# Altium schematic sheet InputCircuitsAndSupply.SchDoc
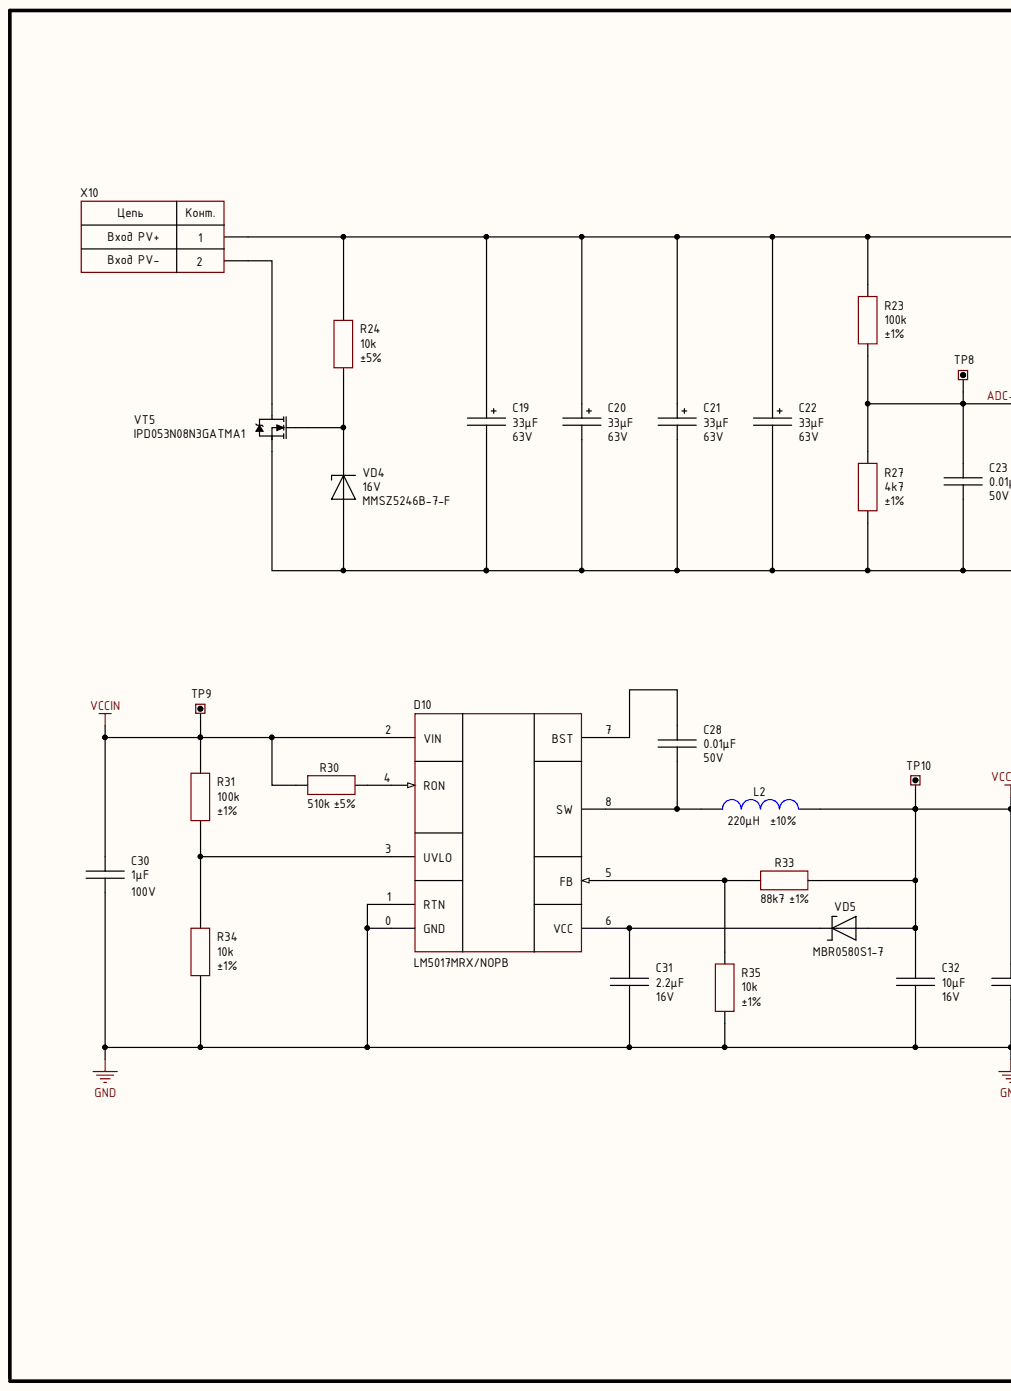
[diagram p0: InputCircuitsAndSupply.SchDoc, left]
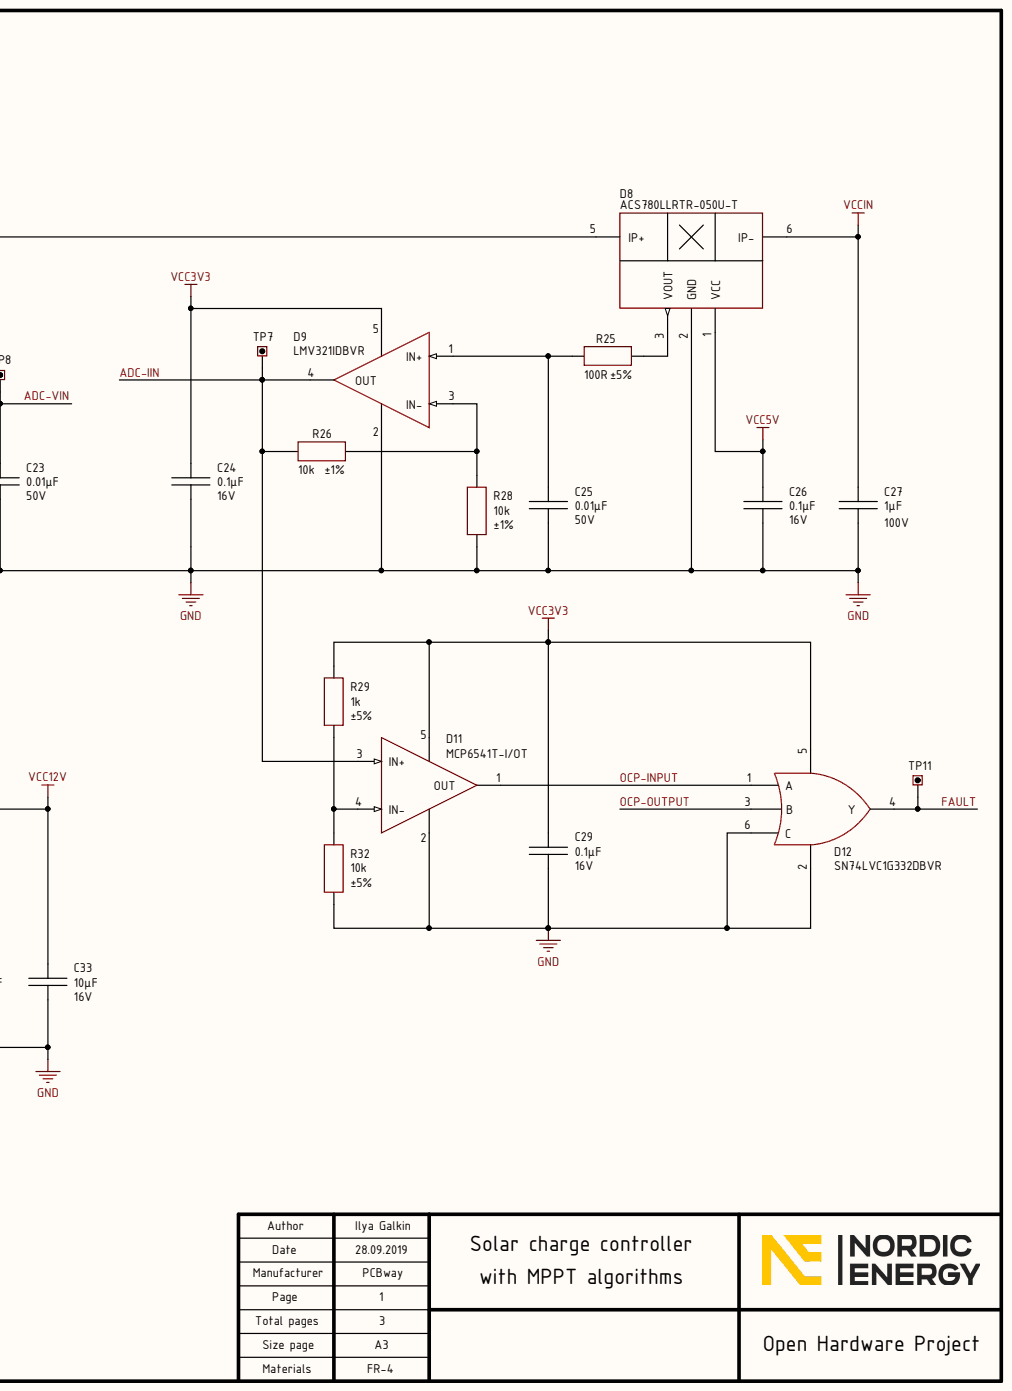
[diagram p1: InputCircuitsAndSupply.SchDoc, right]

## Parts
C19  value 33μF  Voltage: 63V  [CAP: D8C3.5H12]  (hidden comment: Aluminium capacitor, 33μF, 63V, ±20%, 25mOhm, 12x8x3.5 mm, -55...+105°C)
C20  value 33μF  Voltage: 63V  [CAP: D8C3.5H12]  (hidden comment: Aluminium capacitor, 33μF, 63V, ±20%, 25mOhm, 12x8x3.5 mm, -55...+105°C)
C21  value 33μF  Voltage: 63V  [CAP: D8C3.5H12]  (hidden comment: Aluminium capacitor, 33μF, 63V, ±20%, 25mOhm, 12x8x3.5 mm, -55...+105°C)
C22  value 33μF  Voltage: 63V  [CAP: D8C3.5H12]  (hidden comment: Aluminium capacitor, 33μF, 63V, ±20%, 25mOhm, 12x8x3.5 mm, -55...+105°C)
C23  value 0.01μF  Voltage: 50V  [CAP: 0805]  (hidden comment: Ceramic capacitor, 0.01μF, X7R, ±10%, 50V, 0805, -55...+125°C)
C24  value 0.1μF  Voltage: 16V  [CAP: 0805]  (hidden comment: Ceramic capacitor, 0.1μF, X7R, ±10%, 16V, 0805, -55...+125°C)
C25  value 0.01μF  Voltage: 50V  [CAP: 0805]  (hidden comment: Ceramic capacitor, 0.01μF, X7R, ±10%, 50V, 0805, -55...+125°C)
C26  value 0.1μF  Voltage: 16V  [CAP: 0805]  (hidden comment: Ceramic capacitor, 0.1μF, X7R, ±10%, 16V, 0805, -55...+125°C)
C27  value 1μF  Voltage: 100V  [CAP: 1206]  (hidden comment: Ceramic capacitor, 1μF, X7R, ±10%, 100V, 1206, -55...+125°C)
C28  value 0.01μF  Voltage: 50V  [CAP: 0805]  (hidden comment: Ceramic capacitor, 0.01μF, X7R, ±10%, 50V, 0805, -55...+125°C)
C29  value 0.1μF  Voltage: 16V  [CAP: 0805]  (hidden comment: Ceramic capacitor, 0.1μF, X7R, ±10%, 16V, 0805, -55...+125°C)
C30  value 1μF  Voltage: 100V  [CAP: 1206]  (hidden comment: Ceramic capacitor, 1μF, X7R, ±10%, 100V, 1206, -55...+125°C)
C31  value 2.2μF  Voltage: 16V  [CAP: 0805]  (hidden comment: Ceramic capacitor, 2.2μF, X7R, ±10%, 16V, 0805, -55...+125°C)
C32  value 10μF  Voltage: 16V  [CAP: 0805]  (hidden comment: Ceramic capacitor, 10μF, X7R, ±10%, 16V, 0805, -55...+125°C)
C33  value 10μF  Voltage: 16V  [CAP: 0805]  (hidden comment: Ceramic capacitor, 10μF, X7R, ±10%, 16V, 0805, -55...+125°C)
D8  PartNumber: ACS780LLRTR-050U-T  [SENSOR: ACS781]  (hidden comment: IC current sensors, 50A, ±1.5%, 120 kHz, 60mV/A, -40...+150°C, 7-PSOF)
D9  IC op amplifier, Rail-to-Rail, 1 MHz, 2.7...5.5V, -40...+125°C, SOT23-5  [SOT23-5]  (value not drawn)
D10  PartNumber: LM5017MRX/NOPB  [8-SOIC-PAD]  (hidden comment: https://www.digikey.com/product-detail/en/texas-instruments/LM5017MRX-NOPB/296-41308-1-ND/5222776, input 7.5...100V, 1MHz, output 1.225...90V, 0.6A, -40...+125°C, 8-SOIC)
D11  IC comparator, Rail-to-Rail, 1.6...5.5V, -40...+85°C, SOT23-5  [SOT23-5]  (value not drawn)
D12  IC logic OR, 1.65...5.5V, -40...+125°C, SOT23-6  [SOT23-6]  (value not drawn)
L2  value 220μH  Tolerance: ±10%  [IND: SDR0805]  (hidden comment: Fixed inductor, 220μH, ±10%, SDR0805, 490mA, -40...+125°C)
R23  value 100k  Tolerance: ±1%  [RES: 0805]  (hidden comment: Resistor film, 100k, ±1%, 0805, 0.125W, -55...+125°C)
R24  value 10k  Tolerance: ±5%  [RES: 0805]  (hidden comment: Resistor film, 10k, ±5%, 0805, 0.125W, -55...+125°C)
R25  value 100R  Tolerance: ±5%  [RES: 0805]  (hidden comment: Resistor film, 100R, ±5%, 0805, 0.125W, -55...+125°C)
R26  value 10k  Tolerance: ±1%  [RES: 0805]  (hidden comment: Resistor film, 10k, ±1%, 0805, 0.125W, -55...+125°C)
R27  value 4k7  Tolerance: ±1%  [RES: 0805]  (hidden comment: Resistor film, 4k7, ±1%, 0805, 0.125W, -55...+125°C)
R28  value 10k  Tolerance: ±1%  [RES: 0805]  (hidden comment: Resistor film, 10k, ±1%, 0805, 0.125W, -55...+125°C)
R29  value 1k  Tolerance: ±5%  [RES: 0805]  (hidden comment: Resistor film, 1k, ±5%, 0805, 0.125W, -55...+125°C)
R30  value 510k  Tolerance: ±5%  [RES: 0805]  (hidden comment: Resistor film, 510k, ±5%, 0805, 0.125W, -55...+125°C)
R31  value 100k  Tolerance: ±1%  [RES: 0805]  (hidden comment: Resistor film, 100k, ±1%, 0805, 0.125W, -55...+125°C)
R32  value 10k  Tolerance: ±5%  [RES: 0805]  (hidden comment: Resistor film, 10k, ±5%, 0805, 0.125W, -55...+125°C)
R33  value 88k7  Tolerance: ±1%  [RES: 0805]  (hidden comment: Resistor film, 88k7, ±1%, 0805, 0.125W, -55...+125°C)
R34  value 10k  Tolerance: ±1%  [RES: 0805]  (hidden comment: Resistor film, 10k, ±1%, 0805, 0.125W, -55...+125°C)
R35  value 10k  Tolerance: ±1%  [RES: 0805]  (hidden comment: Resistor film, 10k, ±1%, 0805, 0.125W, -55...+125°C)
TP7  Test point, Keystone, 5001  [CON: 5004 (Keystone)]  (value not drawn)
TP8  Test point, Keystone, 5001  [CON: 5004 (Keystone)]  (value not drawn)
TP9  Test point, Keystone, 5001  [CON: 5001 (Keystone)]  (value not drawn)
TP10  Test point, Keystone, 5001  [CON: 5001 (Keystone)]  (value not drawn)
TP11  Test point, Keystone, 5001  [CON: 5001 (Keystone)]  (value not drawn)
VD4  PartNumber: MMSZ5246B-7-F  Voltage: 16V  [DIODE: SOD123]  (hidden comment: Zener diode, 16V, 500mW, 0.9V, 17Ohm, SOD-123, -65...+150°C)
VD5  PartNumber: MBR0580S1-7  [DIODE: SOD123]  (hidden comment: Schottky diode, 80V, 500mA, 1V, 15pF, SOD-123, -55...+150°C)
VT5  PartNumber: IPD053N08N3GATMA1  [DPAK]  (hidden comment: MOSFET N-channel transistor, 80V, 90A, 69nC, 150W, 5.3mOhm, 4750pF, Dpak, -55...+150°C)
X10  Connector, 2pin, 9.53mm, 300VAC, 25A  [CON: 6PCV-02-006]  (value not drawn)

## Nets
GND: C19.2 C20.2 C21.2 C22.2 C23.1 C24.1 C25.1 C26.1 C27.1 C29.1 C30.1 C31.1 C32.1 C33.1 D8.2(GND) D9.2 D10.0(GND) D10.1(RTN) D11.2 D12.2 D12.6(C) R27.2 R28.2 R32.2 R34.2 R35.2 VD4.1 VT5.2
VCC3V3: C24.2 C29.2 D9.5 D11.5 D12.5 R29.1
VCC5V: C26.2 D8.1(VCC)
VCC12V: C32.2 C33.2 L2.1 R33.2 TP10.1 VD5.1
VCCIN: C27.2 C30.2 D8.6(IP-) D10.2(VIN) R30.1 R31.2 TP9.1
ADC-IIN: D9.4(OUT) D11.3(IN+) R26.1 TP7.1
ADC-VIN: C23.2 R23.2 R27.1 TP8.1
FAULT: D12.4(Y) TP11.1
OCP-INPUT: D11.1(OUT) D12.1(A)
OCP-OUTPUT: D12.3(B)
NetC19_1: C19.1 C20.1 C21.1 C22.1 D8.5(IP+) R23.1 R24.1 X10.1
NetC25_2: C25.2 D9.1(IN+) R25.1
NetC28_1: C28.1 D10.8(SW) L2.2
NetC28_2: C28.2 D10.7(BST)
NetC31_2: C31.2 D10.6(VCC) VD5.2
NetD8_3: D8.3(VOUT) R25.2
NetD9_3: D9.3(IN-) R26.2 R28.1
NetD10_3: D10.3(UVLO) R31.1 R34.1
NetD10_4: D10.4(RON) R30.2
NetD10_5: D10.5(FB) R33.1 R35.1
NetD11_4: D11.4(IN-) R29.2 R32.1
NetR24_2: R24.2 VD4.2 VT5.1
NetVT5_3: VT5.3 X10.2

## Library data of the parts
C19-C22 = Aluminium: Manufacturer: Panasonic; PartNumber: 63SXE33M; Component Kind: Standard; HelpURL: https://www.digikey.com/products/en?keywords=%2063SXE33M; Impedance: 25mOhm; Library Name: Capacitor.IntLib; Package: 12x8x3.5 mm; Temperature: -55...+105°C; Tolerance: ±20%; TypeCapacitor: Aluminium capacitor; ValueManufacturerPartNumber: Aluminium capacitor, 33μF, 63V, ±20%, 25mOhm, 12x8x3.5 mm, -55...+105°C
C23 C25 C28 = Ceramic_0805: Manufacturer: Yageo; PartNumber: CC0805KRX7R9BB103; Component Kind: Standard; HelpURL: https://www.digikey.com/product-detail/en/yageo/CC0805KRX7R9BB103/311-1136-1-ND/303046; Library Name: Capacitor.IntLib; Package: 0805; Temperature: -55...+125°C; Tolerance: ±10%; TypeCapacitor: Ceramic capacitor; TypeDielectric: X7R; ValueManufacturerPartNumber: Ceramic capacitor, 0.01μF, X7R, ±10%, 50V, 0805, -55...+125°C
C24 C26 C29 = Ceramic_0805: Manufacturer: Yageo; PartNumber: CC0805KRX7R7BB104; Component Kind: Standard; HelpURL: https://www.digikey.com/product-detail/en/yageo/CC0805KRX7R7BB104/311-1142-1-ND/303052; Library Name: Capacitor.IntLib; Package: 0805; Temperature: -55...+125°C; Tolerance: ±10%; TypeCapacitor: Ceramic capacitor; TypeDielectric: X7R; ValueManufacturerPartNumber: Ceramic capacitor, 0.1μF, X7R, ±10%, 16V, 0805, -55...+125°C
C27 C30 = Ceramic_1206: Manufacturer: Samsung; PartNumber: CL31B105KCHNNNE; Component Kind: Standard; HelpURL: https://www.digikey.com/product-detail/en/samsung-electro-mechanics/CL31B105KCHNNNE/1276-1838-1-ND/3889924; Library Name: Capacitor.IntLib; Package: 1206; Temperature: -55...+125°C; Tolerance: ±10%; TypeCapacitor: Ceramic capacitor; TypeDielectric: X7R; ValueManufacturerPartNumber: Ceramic capacitor, 1μF, X7R, ±10%, 100V, 1206, -55...+125°C
C31 = Ceramic_0805: Manufacturer: Yageo; PartNumber: CC0805KKX7R7BB225; Component Kind: Standard; HelpURL: https://www.digikey.com/product-detail/en/yageo/CC0805KKX7R7BB225/311-1881-1-ND/5195783; Library Name: Capacitor.IntLib; Package: 0805; Temperature: -55...+125°C; Tolerance: ±10%; TypeCapacitor: Ceramic capacitor; TypeDielectric: X7R; ValueManufacturerPartNumber: Ceramic capacitor, 2.2μF, X7R, ±10%, 16V, 0805, -55...+125°C
C32 C33 = Ceramic_0805: Manufacturer: Samsung; PartNumber: CL21B106KOQNNNG; Component Kind: Standard; HelpURL: https://www.digikey.com/product-detail/en/samsung-electro-mechanics/CL21B106KOQNNNG/1276-6472-1-ND/5958100; Library Name: Capacitor.IntLib; Package: 0805; Temperature: -55...+125°C; Tolerance: ±10%; TypeCapacitor: Ceramic capacitor; TypeDielectric: X7R; ValueManufacturerPartNumber: Ceramic capacitor, 10μF, X7R, ±10%, 16V, 0805, -55...+125°C
D8 = ACS780: Current sensors; Manufacturer: Allegro MicroSystems; GainBandwidth: 120 kHz; Supply: 4.5...5.5V; Accuracy: ±1.5%; Component Kind: Standard; ComponentType: IC current sensors; HelpURL: https://www.digikey.com/product-detail/en/allegro-microsystems-llc/ACS780LLRTR-050U-T/620-1818-1-ND/6189107; Library Name: IC. Sensor.IntLib; Package: 7-PSOF; Sensing: 50A; Sensitivity: 60mV/A; Temperature: -40...+150°C; ValueManufacturerPartNumber: IC current sensors, 50A, ±1.5%, 120 kHz, 60mV/A, -40...+150°C, 7-PSOF
D9 = LMV321IDBVR: OP amplifier; Manufacturer: Texas Instruments; GainBandwidth: 1 MHz; Component Kind: Standard; ComponentType: IC op amplifier; HelpURL: https://www.digikey.com/product-detail/en/texas-instruments/LMV321IDBVR/296-9567-1-ND/379849; Library Name: IC. Amplifier.IntLib; OutputType: Rail-to-Rail; Package: SOT23-5; PartNumber: LMV321IDBVR; Supply: 2.7...5.5V; Temperature: -40...+125°C; ValueManufacturerPartNumber: IC op amplifier, Rail-to-Rail, 1 MHz, 2.7...5.5V, -40...+125°C, SOT23-5
D10 = LM5017MRX: Buck converter; Manufacturer: Texas Instruments; Component Kind: Standard; ComponentType: https://www.digikey.com/product-detail/en/texas-instruments/LM5017MRX-NOPB/296-41308-1-ND/5222776; CurrentOutput: 0.6A; Frequency: 1MHz; HelpURL: https://www.digikey.com/product-detail/en/texas-instruments/LM5017SD-NOPB/LM5017SD-NOPBCT-ND/3137455; Library Name: IC. Power supply.IntLib; Package: 8-SOIC; Temperature: -40...+125°C; ValueManufacturerPartNumber: https://www.digikey.com/product-detail/en/texas-instruments/LM5017MRX-NOPB/296-41308-1-ND/5222776, input 7.5...100V, 1MHz, output 1.225...90V, 0.6A, -40...+125°C, 8-SOIC; VoltageInput: input 7.5...100V; VoltageOutput: output 1.225...90V
D11 = MCP6541T-I/OT: Comparator; Manufacturer: Microchip Technology; Component Kind: Standard; ComponentType: IC comparator; HelpURL: https://www.digikey.com/product-detail/en/microchip-technology/MCP6541T-I-OT/MCP6541T-I-OTCT-ND/3622387; Library Name: IC. Comparator.IntLib; OutputType: Rail-to-Rail; Package: SOT23-5; PartNumber: MCP6541T-I/OT; Supply: 1.6...5.5V; Temperature: -40...+85°C; ValueManufacturerPartNumber: IC comparator, Rail-to-Rail, 1.6...5.5V, -40...+85°C, SOT23-5
D12 = SN74LVC1G332DBVR: OR; Manufacturer: Texas Instruments; Component Kind: Standard; ComponentType: IC logic OR; HelpURL: https://www.digikey.com/product-detail/en/texas-instruments/SN74LVC1G332DBVR/296-18587-1-ND/857355; Library Name: IC. Logic.IntLib; Package: SOT23-6; PartNumber: SN74LVC1G332DBVR; Supply: 1.65...5.5V; Temperature: -40...+125°C; ValueManufacturerPartNumber: IC logic OR, 1.65...5.5V, -40...+125°C, SOT23-6
L2 = Inductor_SDR0805: Manufacturer: Bourns; PartNumber: SDR0805-221KL; Component Kind: Standard; HelpURL: https://www.digikey.com/product-detail/en/bourns-inc/SDR0805-221KL/SDR0805-221KLCT-ND/2127164; Library Name: Inductor.IntLib; MaxCurrent: 490mA; Package: SDR0805; Temperature: -40...+125°C; TypeInductor: Fixed inductor; ValueManufacturerPartNumber: Fixed inductor, 220μH, ±10%, SDR0805, 490mA, -40...+125°C
R23 R31 = Resistor_0805: Manufacturer: Yageo; PartNumber: RC0805FR-07100KL; Component Kind: Standard; HelpURL: https://www.digikey.com/product-detail/en/yageo/RC0805FR-07100KL/311-100KCRCT-ND/730491; Library Name: Resistor.IntLib; Package: 0805; Power: 0.125W; Temperature: -55...+125°C; TypeResistor: Resistor film; ValueManufacturerPartNumber: Resistor film, 100k, ±1%, 0805, 0.125W, -55...+125°C
R24 R32 = Resistor_0805: Manufacturer: Yageo; PartNumber: RC0805JR-0710KL; Component Kind: Standard; HelpURL: https://www.digikey.com/product-detail/en/yageo/RC0805JR-0710KL/311-10KARCT-ND/731188; Library Name: Resistor.IntLib; Package: 0805; Power: 0.125W; Temperature: -55...+125°C; TypeResistor: Resistor film; ValueManufacturerPartNumber: Resistor film, 10k, ±5%, 0805, 0.125W, -55...+125°C
R25 = Resistor_0805: Manufacturer: Yageo; PartNumber: RC0805JR-07100RL; Component Kind: Standard; HelpURL: https://www.digikey.com/product-detail/en/yageo/RC0805JR-07100RL/311-100ARCT-ND/731185; Library Name: Resistor.IntLib; Package: 0805; Power: 0.125W; Temperature: -55...+125°C; TypeResistor: Resistor film; ValueManufacturerPartNumber: Resistor film, 100R, ±5%, 0805, 0.125W, -55...+125°C
R26 R28 R34 R35 = Resistor_0805: Manufacturer: Yageo; PartNumber: RC0805FR-0710KL; Component Kind: Standard; HelpURL: https://www.digikey.com/product-detail/en/yageo/RC0805FR-0710KL/311-10.0KCRCT-ND/730482; Library Name: Resistor.IntLib; Package: 0805; Power: 0.125W; Temperature: -55...+125°C; TypeResistor: Resistor film; ValueManufacturerPartNumber: Resistor film, 10k, ±1%, 0805, 0.125W, -55...+125°C
R27 = Resistor_0805: Manufacturer: Yageo; PartNumber: RC0805FR-074K7L; Component Kind: Standard; HelpURL: https://www.digikey.com/product-detail/en/yageo/RC0805FR-074K7L/311-4.70KCRCT-ND/730876; Library Name: Resistor.IntLib; Package: 0805; Power: 0.125W; Temperature: -55...+125°C; TypeResistor: Resistor film; ValueManufacturerPartNumber: Resistor film, 4k7, ±1%, 0805, 0.125W, -55...+125°C
R29 = Resistor_0805: Manufacturer: Yageo; PartNumber: RC0805JR-071KL; Component Kind: Standard; HelpURL: https://www.digikey.com/product-detail/en/yageo/RC0805JR-071KL/311-1.0KARCT-ND/731165; Library Name: Resistor.IntLib; Package: 0805; Power: 0.125W; Temperature: -55...+125°C; TypeResistor: Resistor film; ValueManufacturerPartNumber: Resistor film, 1k, ±5%, 0805, 0.125W, -55...+125°C
R30 = Resistor_0805: Manufacturer: Yageo; PartNumber: RC0805JR-07510KL; Component Kind: Standard; HelpURL: https://www.digikey.com/product-detail/en/yageo/RC0805JR-07510KL/311-510KARCT-ND/731291; Library Name: Resistor.IntLib; Package: 0805; Power: 0.125W; Temperature: -55...+125°C; TypeResistor: Resistor film; ValueManufacturerPartNumber: Resistor film, 510k, ±5%, 0805, 0.125W, -55...+125°C
R33 = Resistor_0805: Manufacturer: Yageo; PartNumber: RC0805FR-0788K7L; Component Kind: Standard; HelpURL: https://www.digikey.com/product-detail/en/yageo/RC0805FR-0788K7L/311-88.7KCRCT-ND/731128; Library Name: Resistor.IntLib; Package: 0805; Power: 0.125W; Temperature: -55...+125°C; TypeResistor: Resistor film; ValueManufacturerPartNumber: Resistor film, 88k7, ±1%, 0805, 0.125W, -55...+125°C
TP7-TP11 = Connector_Test_Point: PartNumber: 5001; Component Kind: Standard; HelpURL: https://www.digikey.com/product-detail/en/keystone-electronics/5001/36-5001-ND/255327; Library Name: Connector.IntLib; Manufacturer: Keystone; TypeConnector: Test point; ValueManufacturerPartNumber: Test point, Keystone, 5001
VD4 = Zener_single: Manufacturer: Diodes Incorporated; Component Kind: Standard; Current: 500mW; HelpURL: https://www.digikey.com/product-detail/en/diodes-incorporated/MMSZ5246B-7-F/MMSZ5246B-FDICT-ND/717912; Library Name: Diode.IntLib; Package: SOD-123; RecoveryTime: 17Ohm; Temperature: -65...+150°C; TypeDiode: Zener diode; ValueManufacturerPartNumber: Zener diode, 16V, 500mW, 0.9V, 17Ohm, SOD-123, -65...+150°C; VoltageDrop: 0.9V
VD5 = Schottky_single: Manufacturer: Diodes Incorporated; Component Kind: Standard; Current: 500mA; HelpURL: https://www.digikey.com/product-detail/en/diodes-incorporated/MBR0580S1-7/MBR0580S1-7DICT-ND/5080371; Library Name: Diode.IntLib; Package: SOD-123; RecoveryTime: 15pF; Temperature: -55...+150°C; TypeDiode: Schottky diode; ValueManufacturerPartNumber: Schottky diode, 80V, 500mA, 1V, 15pF, SOD-123, -55...+150°C; Voltage: 80V; VoltageDrop: 1V
VT5 = MOSFET_N_132: Manufacturer: Infineon Technologies; Component Kind: Standard; CurrentDS: 90A; GateCharge: 69nC; HelpURL: https://www.digikey.com/product-detail/en/infineon-technologies/IPD053N08N3GATMA1/IPD053N08N3GATMA1CT-ND/5353500; InputCapacitor: 4750pF; Library Name: Transistor.IntLib; MaxPower: 150W; Package: Dpak; RDS: 5.3mOhm; Temperature: -55...+150°C; TypeTransistor: MOSFET N-channel transistor; ValueManufacturerPartNumber: MOSFET N-channel transistor, 80V, 90A, 69nC, 150W, 5.3mOhm, 4750pF, Dpak, -55...+150°C; VoltageDS: 80V
X10 = Connector_6PCV-02: Manufacturer: TE Connectivity; PartNumber: 6PCV-02-006; CommentText: "Solar panel"; Component Kind: Standard; CurrentMax: 25A; HelpURL: https://www.digikey.com/products/en?keywords=6PCV-02-006; Library Name: Connector.IntLib; Multichannel: 2pin; Pitch: 9.53mm; TypeConnector: Connector; ValueManufacturerPartNumber: Connector, 2pin, 9.53mm, 300VAC, 25A; VoltageMax: 300VAC
